# Altium schematic sheet STM.SchDoc
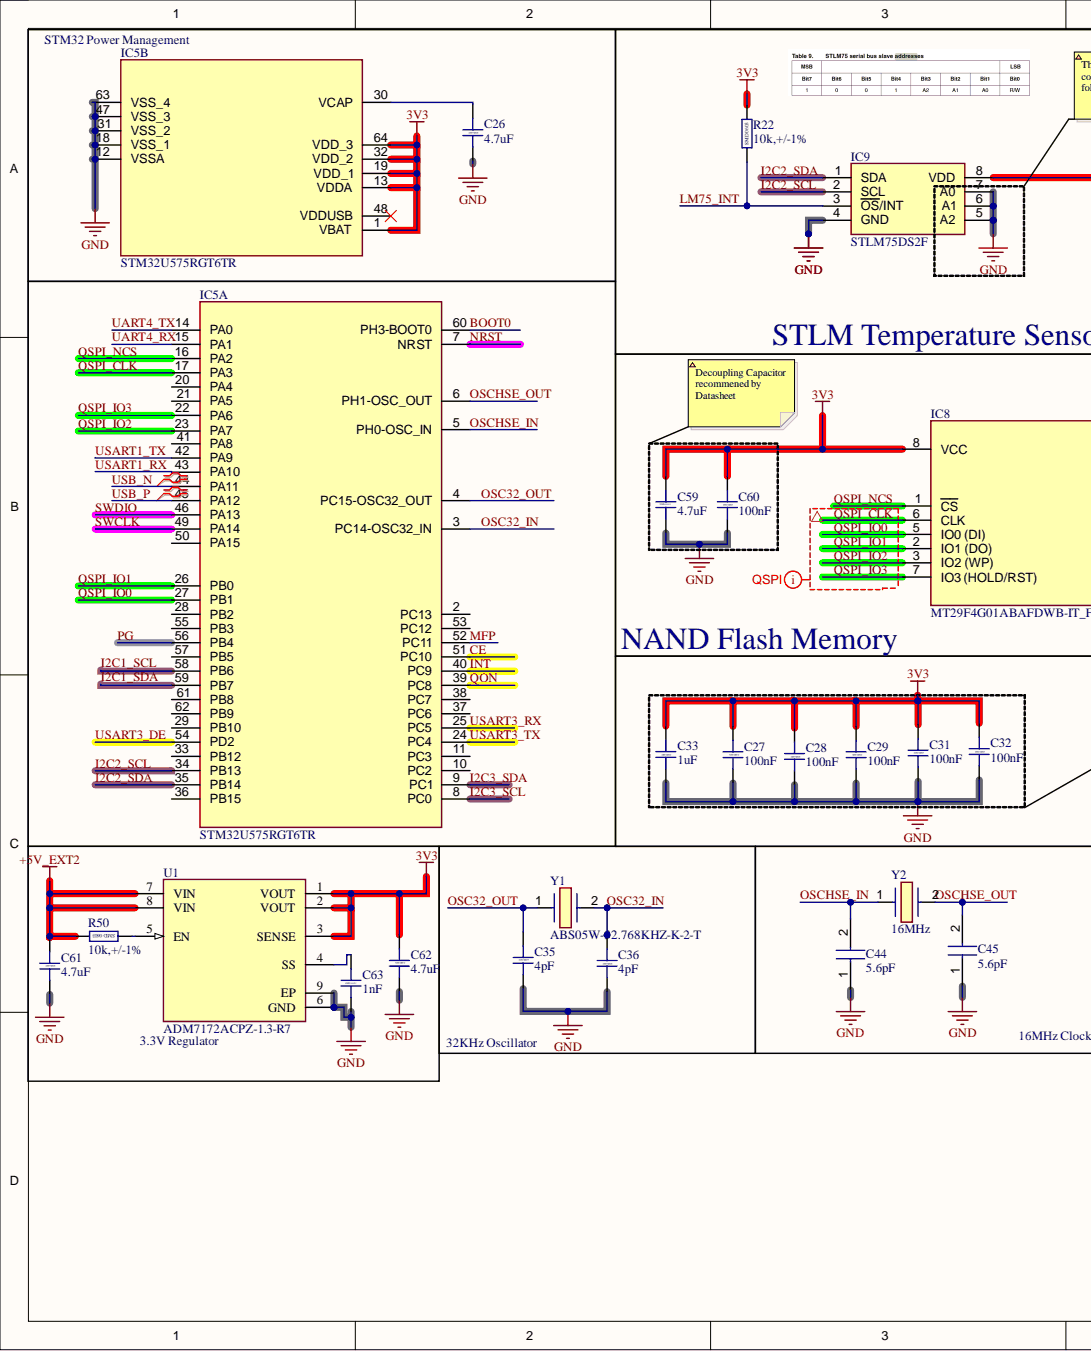
[diagram p0: STM.SchDoc, left]
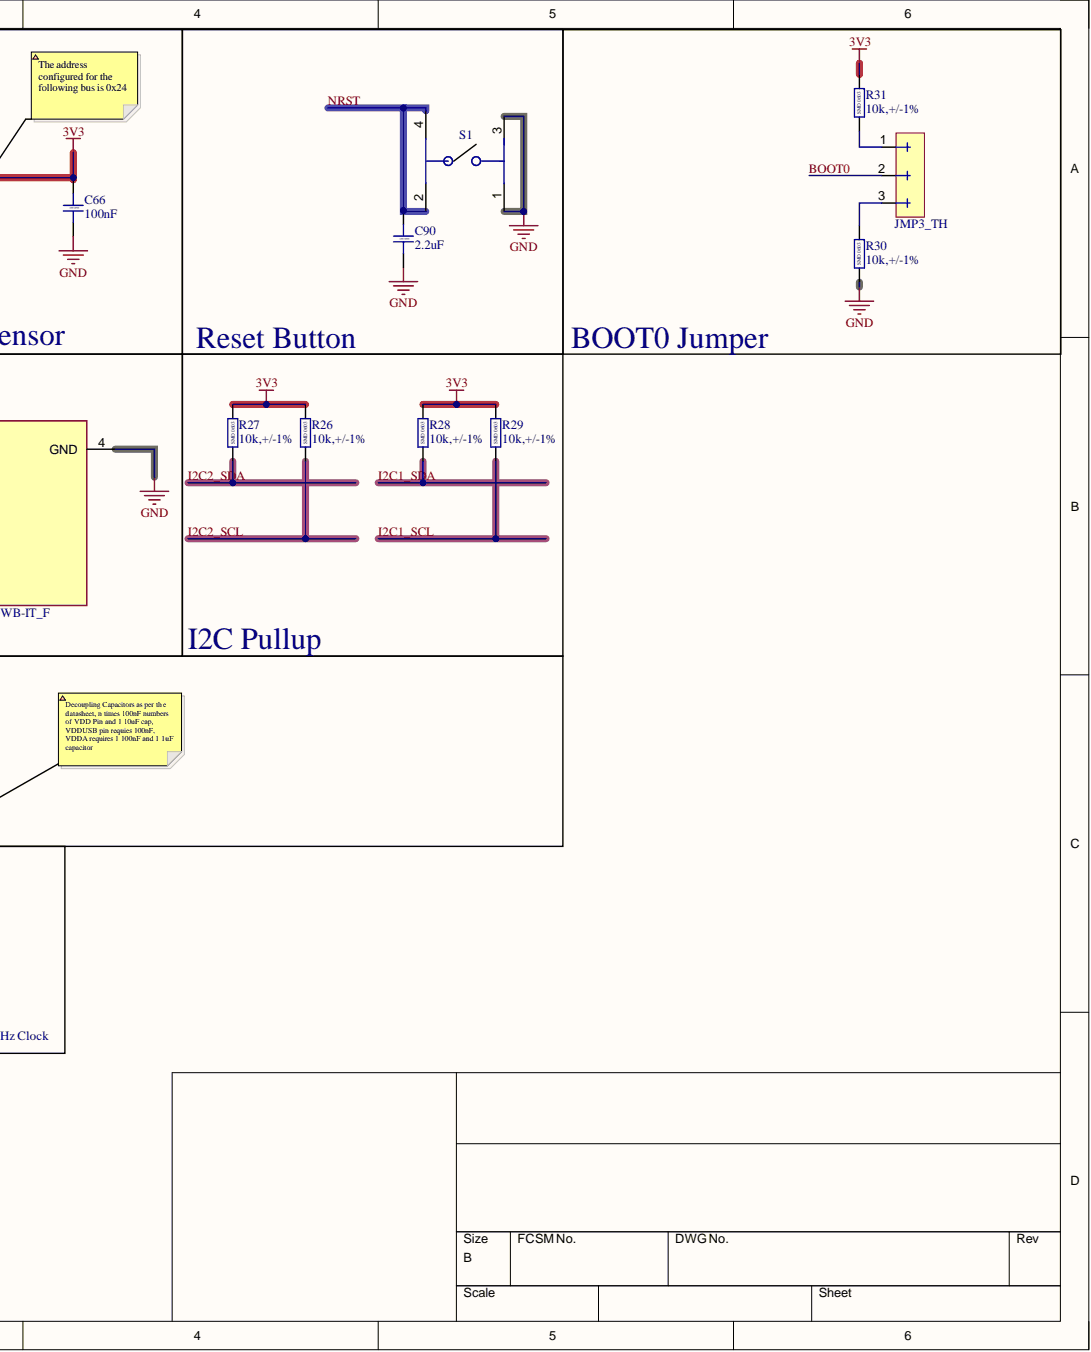
[diagram p1: STM.SchDoc, right]

## Parts
C26  4.7uF  [CAP_0603]
C27  100nF  [CAP_0603]
C28  100nF  [CAP_0603]
C29  100nF  [CAP_0603]
C31  100nF  [CAP_0603]
C32  100nF  [CAP_0603]
C33  1uF  [CAP_0603]
C35  4pF  [CAP_0603]
C36  4pF  [CAP_0603]
C44  5.6pF  [CAP_0603]
C45  5.6pF  [CAP_0603]
C59  4.7uF  [CAP_0603]
C60  100nF  [CAP_0603]
C61  4.7uF  [CAP_0603]
C62  4.7uF  [CAP_0603]
C63  1nF  [CAP_0603]
C66  100nF  [CAP_0603]
C90  2.2uF  [CAP_0603]
IC5  STM32U575RGT6TR  [QFP50P1200X1200X160-64N]  (parts A,B)
IC8  MT29F4G01ABAFDWB-IT_F  [SON127P800X600X65-8N]
IC9  STLM75DS2F  [SOP65P490X110-8N]
R22  10k,+/-1%  [RES_0603]
R26  10k,+/-1%  [RES_0603]
R27  10k,+/-1%  [RES_0603]
R28  10k,+/-1%  [RES_0603]
R29  10k,+/-1%  [RES_0603]
R30  10k,+/-1%  [RES_0603]
R31  10k,+/-1%  [RES_0603]
R50  10k,+/-1%  [RES_0603]
S1  (no value)  [218259107]
U1  ADM7172ACPZ-1.3-R7  [FP-CP-8-21-IPC_C]
Y1  ABS05W-32.768KHZ-K-2-T  [ABS05]
Y2  16MHz  [ABM3]
  JMP3_TH  [JMP3_TH]

## Nets
3V3: C27.2 C28.2 C29.2 C31.2 C32.2 C33.2 C59.1 C60.2 C62.2 C66.2 IC5.1(VBAT) IC5.13(VDDA) IC5.19(VDD_1) IC5.32(VDD_2) IC5.64(VDD_3) IC8.8(VCC) IC9.8(VDD) R22.2 R26.2 R27.2 R28.2 R29.2 R31.2 U1.1(VOUT) U1.2(VOUT) U1.3(SENSE)
+5V_EXT2: C61.2 R50.2 U1.7(VIN) U1.8(VIN)
GND: C26.1 C27.1 C28.1 C29.1 C31.1 C32.1 C33.1 C35.1 C36.1 C44.1 C45.1 C59.2 C60.1 C61.1 C62.1 C63.2 C66.1 C90.1 IC5.12(VSSA) IC5.18(VSS_1) IC5.31(VSS_2) IC5.47(VSS_3) IC5.63(VSS_4) IC8.4(GND) IC9.4(GND) IC9.5(A2) IC9.6(A1) IC9.7(A0) R30.1 S1.1 S1.3 U1.6(GND) U1.9(EP)
Net_1: R31.1 .1
Net_3: R30.2 .3
BOOT0: IC5.60(PH3-BOOT0) .2
CE: IC5.51(PC10)
I2C1_SCL: IC5.58(PB6) R29.1
I2C1_SDA: IC5.59(PB7) R28.1
I2C2_SCL: IC5.34(PB13) IC9.2(SCL) R26.1
I2C2_SDA: IC5.35(PB14) IC9.1(SDA) R27.1
I2C3_SCL: IC5.8(PC0)
I2C3_SDA: IC5.9(PC1)
INT: IC5.40(PC9)
LM75_INT: IC9.3(OS/INT) R22.1
MFP: IC5.52(PC11)
NRST: C90.2 IC5.7(NRST) S1.2 S1.4
OSC32_IN: C36.2 IC5.3(PC14-OSC32_IN) Y1.2
OSC32_OUT: C35.2 IC5.4(PC15-OSC32_OUT) Y1.1
OSCHSE_IN: C44.2 IC5.5(PH0-OSC_IN) Y2.1
OSCHSE_OUT: C45.2 IC5.6(PH1-OSC_OUT) Y2.2
PG: IC5.56(PB4)
QON: IC5.39(PC8)
QSPI_CLK: IC5.17(PA3) IC8.6(CLK)
QSPI_IO0: IC5.27(PB1) IC8.5(IO0_(DI))
QSPI_IO1: IC5.26(PB0) IC8.2(IO1_(DO))
QSPI_IO2: IC5.23(PA7) IC8.3(IO2_(WP))
QSPI_IO3: IC5.22(PA6) IC8.7(IO3_(HOLD/RST))
QSPI_NCS: IC5.16(PA2) IC8.1(CS)
SWCLK: IC5.49(PA14)
SWDIO: IC5.46(PA13)
UART4_RX: IC5.15(PA1)
UART4_TX: IC5.14(PA0)
USART1_RX: IC5.43(PA10)
USART1_TX: IC5.42(PA9)
USART3_DE: IC5.54(PD2)
USART3_RX: IC5.25(PC5)
USART3_TX: IC5.24(PC4)
USB_N: IC5.44(PA11)
USB_P: IC5.45(PA12)
NetC26_2: C26.2 IC5.30(VCAP)
NetC63_1: C63.1 U1.4(SS)
NetR50_1: R50.1 U1.5(EN)

Not connected: IC5 2(PC13) 10(PC2) 11(PC3) 20(PA4) 21(PA5) 28(PB2) 29(PB10) 33(PB12) 36(PB15) 37(PC6) 38(PC7) 41(PA8) 48(VDDUSB) 50(PA15) 53(PC12) 55(PB3) 57(PB5) 61(PB8) 62(PB9)

## Library data of the parts
C26 C59 C61 C62 = Capacitor-SMD-0603: GRM188R6YA475KE15D; Component Kind: Standard; Library Name: STeRG-Passives-Standard.SchLib
C27-C29 C31-C33 C60 C66 = Capacitor-SMD-0603: C0603C104K3RACTU; Component Kind: Standard; Library Name: STeRG-Passives-Standard.SchLib
C35 C36 = Capacitor-SMD-0603: CGA3E2NP02A040C080AA; Component Kind: Standard; Library Name: STeRG-Passives-Standard.SchLib
C44 C45 = C0603C569C5GACTU: Capacitor; Manufacturer_Name: KEMET; Arrow Part Number: C0603C569C5GACTU; Arrow Price/Stock: https://www.arrow.com/en/products/c0603c569c5gactu/kemet-corporation?region=nac; Component Kind: Standard; Datasheet Link: https://content.kemet.com/datasheets/KEM_C1003_C0G_SMD.pdf; Height: 0.87mm; Manufacturer_Part_Number: C0603C569C5GACTU; Mouser Part Number: 80-C0603C569C5G; Mouser Price/Stock: https://www.mouser.co.uk/ProductDetail/KEMET/C0603C569C5GACTU?qs=5GGmbjqkbYeYpfQi22ubQQ%3D%3D
C63 = Capacitor-SMD-0603: CEU3E2X7R1H103M080AE; Component Kind: Standard; Library Name: STeRG-Passives-Standard.SchLib
C90 = Capacitor-SMD-0603: CC0603KPX5R7BB225; Component Kind: Standard; Library Name: STeRG-Passives-Standard.SchLib
IC5 = STM32U575RGT6TR: Integrated Circuit; Manufacturer_Name: STMicroelectronics; Arrow Part Number: STM32U575RGT6TR; Arrow Price/Stock: https://www.arrow.com/en/products/stm32u575rgt6tr/stmicroelectronics?utm_currency=USD&region=nac; Component Kind: Standard; Datasheet Link: https://www.st.com/resource/en/datasheet/stm32u575ag.pdf; Height: 1.6mm; Library Name: STeRG-ICs-Standard.SchLib; Manufacturer_Part_Number: STM32U575RGT6TR; Mouser Part Number: 511-STM32U575RGT6TR; Mouser Price/Stock: https://www.mouser.co.uk/ProductDetail/STMicroelectronics/STM32U575RGT6TR?qs=T%252BzbugeAwjjwoz%252BinJLUwQ%3D%3D
IC8 = MT29F4G01ABAFDWB-IT_F: Integrated Circuit; Manufacturer_Name: Micron; Manufacturer_Part_Number: MT29F4G01ABAFDWB-IT:F; Arrow Part Number: MT29F4G01ABAFDWB-IT:F; Arrow Price/Stock: null?region=nac; Component Kind: Standard; Datasheet Link: https://www.farnell.com/datasheets/3151403.pdf; Height: 0.65mm; Mouser Part Number: 340-359563; Mouser Price/Stock: https://www.mouser.co.uk/ProductDetail/Micron/MT29F4G01ABAFDWB-ITF?qs=wUXugUrL1qwrjb7To7JPbA%3D%3D; Library Name: STeRG-ICs-Standard.SchLib
IC9 = STLM75DS2F: Integrated Circuit; Manufacturer_Name: STMicroelectronics; Arrow Part Number: STLM75DS2F; Arrow Price/Stock: https://www.arrow.com/en/products/stlm75ds2f/stmicroelectronics?region=europe; Component Kind: Standard; Datasheet Link: http://www.st.com/st-web-ui/static/active/en/resource/technical/document/datasheet/CD00153511.pdf; Height: 1.1mm; Manufacturer_Part_Number: STLM75DS2F; Mouser Part Number: 511-STLM75DS2F; Mouser Price/Stock: https://www.mouser.co.uk/ProductDetail/STMicroelectronics/STLM75DS2F?qs=dprsmcfV5jP0HiOcErRJmQ%3D%3D; Library Name: STeRG-ICs-Standard.SchLib
R22 R26-R31 R50 = Resistor-SMD-0603: CRCW060310K0FKEA; Component Kind: Standard; Library Name: STeRG-Passives-Standard.SchLib
S1 = 2-1825910-7: Switch; Manufacturer_Name: TE Connectivity; Arrow Part Number: 2-1825910-7; Arrow Price/Stock: https://www.arrow.com/en/products/2-1825910-7/te-connectivity?utm_currency=USD&region=europe; Component Kind: Standard; Datasheet Link: https://www.te.com/commerce/DocumentDelivery/DDEController?Action=srchrtrv&DocNm=1308111-1_SWITCHES_CORE_PROGRAM_CATALOG&DocType=Catalog%20Section&DocLang=English&PartCntxt=2-1825910-7&DocFormat=pdf; Height: 13mm; Library Name: Header_SchematicLibrary.SchLib; Manufacturer_Part_Number: 2-1825910-7; Mouser Part Number: 506-2-1825910-7; Mouser Price/Stock: https://www.mouser.co.uk/ProductDetail/TE-Connectivity-PB/2-1825910-7?qs=epcAG6di8szpGFLvuwXKeQ%3D%3D
U1 = CMP-09138-000293-1: IC REG LINEAR 1.3V 2A 8LFCSP; Dropout Voltage: 172mV; Max Input Voltage: 6.5V; Min Current Limit: 2.4A; Min Input Voltage: 2.3V; Number of Outputs: 1; Output Type: Fixed; Polarity: Positive; Quiescent Current: 700uA; Component Kind: Standard; Lead Free: Contains Lead; Library Name: Altium Content Vault; Max Operating Temperature: 125°C; Max Output Current: 2A; Min Operating Temperature: -40°C; Mount: Surface Mount; Number of Pins: 8; Number of Regulators: 1; Output Current: 2A; Output Voltage: 1.3V; Packaging: Tape and Reel; RoHS Compliant: Yes; Series: -
Y1 = ABS05W-32.768KHZ-K-2-T: Crystal or Oscillator; Manufacturer_Name: ABRACON; Arrow Part Number: ABS05W-32.768KHZ-K-2-T; Arrow Price/Stock: https://www.arrow.com/en/products/abs05w-32.768khz-k-2-t/abracon?utm_currency=USD&region=europe; Component Kind: Standard; Datasheet Link: https://abracon.com/Resonators/ABS05W.pdf; Library Name: SamacSys.SchLib; Manufacturer_Part_Number: ABS05W-32.768KHZ-K-2-T; Mouser Part Number: 815-ABS05W32.768KK2T; Mouser Price/Stock: https://www.mouser.co.uk/ProductDetail/ABRACON/ABS05W-32.768KHZ-K-2-T?qs=r5DSvlrkXmJQzCoGJH4H9g%3D%3D
Y2 = ABM3-16.000MHZ-D2Y-T: Crystal or Oscillator; Manufacturer_Name: ABRACON; Arrow Part Number: ABM3-16.000MHZ-D2Y-T; Arrow Price/Stock: https://www.arrow.com/en/products/abm3-16.000mhz-d2y-t/abracon?utm_currency=USD&region=nac; Component Kind: Standard; Datasheet Link: https://abracon.com/Resonators/abm3.pdf; Height: 1.3mm; Library Name: SamacSys.SchLib; Manufacturer_Part_Number: ABM3-16.000MHZ-D2Y-T; Mouser Part Number: 815-ABM3-16-D2Y-T; Mouser Price/Stock: https://www.mouser.co.uk/ProductDetail/ABRACON/ABM3-16.000MHZ-D2Y-T?qs=ihRgKfnEsN66T7SnvB1%2FxQ%3D%3D
 = JMP3_TH: Component Kind: Standard; Library Name: STeRG-Passives-Standard.SchLib
